# Altium schematic sheet Battery Pack BP8.SchDoc
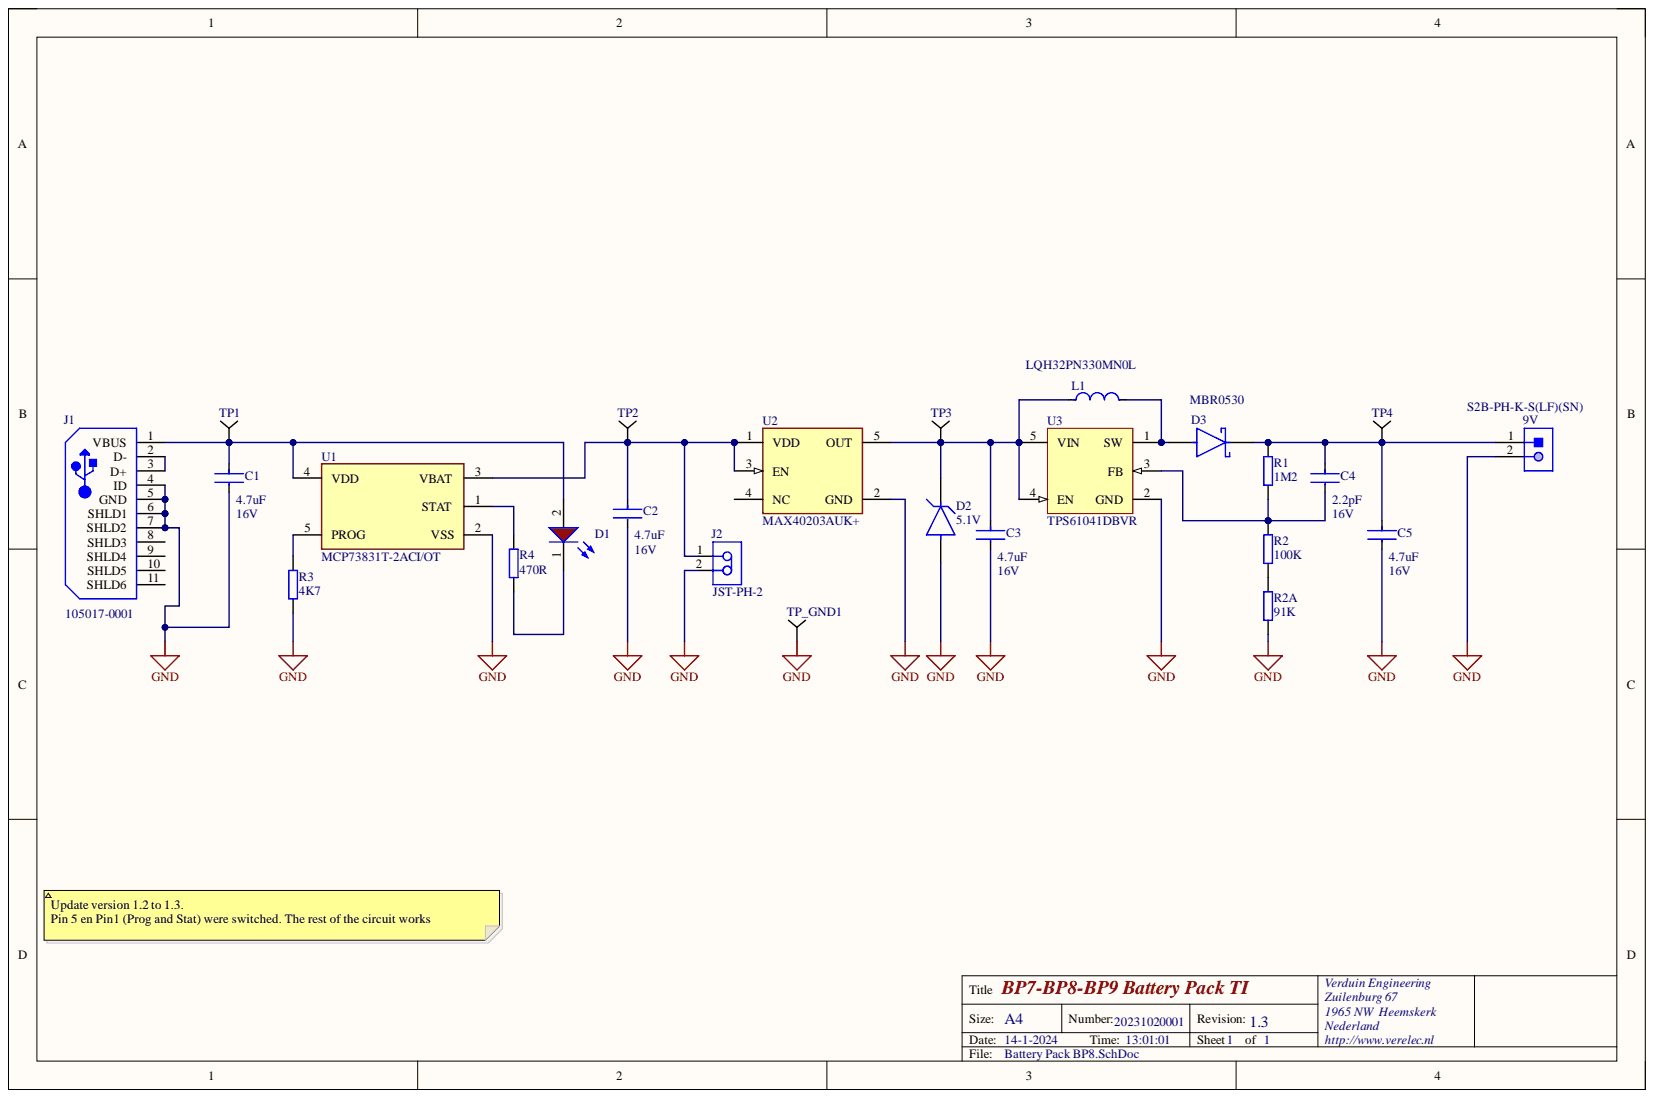
[diagram p0: Battery Pack BP8.SchDoc]

## Parts
9V  S2B-PH-K-S(LF)(SN)  [JST-S2B-PH-K-S_V]
C1  value 4.7uF  Capacitance: 4.7uF  Voltage Rating: 16V  [FP-0805-L_2_01_0_2-W_1_25-MFG]  (hidden comment: GCM21BR71C475KA73K)
C2  value 4.7uF  Capacitance: 4.7uF  Voltage Rating: 16V  [FP-0805-L_2_01_0_2-W_1_25-MFG]  (hidden comment: GCM21BR71C475KA73K)
C3  value 4.7uF  Capacitance: 4.7uF  Voltage Rating: 16V  [FP-0805-L_2_01_0_2-W_1_25-MFG]  (hidden comment: GCM21BR71C475KA73K)
C4  value 2.2pF  Capacitance: 2.2pF  Voltage Rating: 16V  [FP-0805-L_2_01_0_2-W_1_25-MFG]  (hidden comment: C0805C229C2GACTU)
C5  value 4.7uF  Capacitance: 4.7uF  Voltage Rating: 16V  [FP-0805-L_2_01_0_2-W_1_25-MFG]  (hidden comment: GCM21BR71C475KA73K)
D1  150080RS75000  [WL-SMCW_0805_150080xx75000]  (value not drawn)
D2  Nom Zener Voltage: 5.1V  [FP-SOD123-MFG]  (hidden comment: BZT52C5V1-7-F)
D3  MBR0530  [FP-425-04-MFG]
J1  105017-0001  [USB-MICRO-B_V]
J2  value JST-PH-2  [JST-B2B-PH-K-S_V]  (hidden comment: B2BPHKSLFSN)
L1  LQH32PN330MN0L  [FP-LQH32PB_N0-MFG]
R1  value 1M2  [RES0805]  (hidden comment: RES)
R2  value 100K  [RES0805]  (hidden comment: RES)
R2A  value 91K  [RES0805]  (hidden comment: RES)
R3  value 4K7  [RES0805]  (hidden comment: RES)
R4  value 470R  [RES0805]  (hidden comment: RES)
TP1  TESTPOINT  [TP-TOP]  (value not drawn)
TP2  TESTPOINT  [TP-TOP]  (value not drawn)
TP3  TESTPOINT  [TP-TOP]  (value not drawn)
TP4  TESTPOINT  [TP-TOP]  (value not drawn)
TP_GND1  TESTPOINT  [TP-TOP]  (value not drawn)
U1  MCP73831T-2ACI/OT  [SOT23-5]
U2  MAX40203AUK+  [FP-U5-2-MFG]
U3  TPS61041DBVR  [DBV0005A_M]

## Nets
GND: 9V.2 C1.2 C2.2 C3.2 C5.2 D2.2 J1.4(ID) J1.5(GND) J1.6(SHLD1) J1.7(SHLD2) J2.2 R2A.1 R3.1 TP_GND1.0 U1.2(VSS) U2.2(GND) U3.2(GND)
Net9V_1: 9V.1 C4.1 C5.1 D3.1 R1.2 TP4.0
NetC1_1: C1.1 D1.2 J1.1(VBUS) TP1.0 U1.4(VDD)
NetC2_1: C2.1 J2.1 TP2.0 U1.3(VBAT) U2.1(VDD) U2.3(EN)
NetC3_1: C3.1 D2.1 L1.1 TP3.0 U2.5(OUT) U3.4(EN) U3.5(VIN)
NetC4_2: C4.2 R1.1 R2.2 U3.3(FB)
NetD1_1: D1.1 R4.1
NetD3_2: D3.2 L1.2 U3.1(SW)
NetJ1_2: J1.2(D-) J1.3(D+)
NetR2_1: R2.1 R2A.2
NetR3_2: R3.2 U1.5(PROG)
NetR4_2: R4.2 U1.1(STAT)

Not connected: J1 8(SHLD3) 9(SHLD4) 10(SHLD5) 11(SHLD6); U2 4(NC)

## Library data of the parts
9V = CMP-1755-00004-1: Male Header, Pitch 2 mm, 1 x 2 Position, Height 4.8 mm, Tail Length 3.4 mm, -25 to 85 degC, RoHS, Bulk; Capacitance: 10 uF; Case\Package: Radial; Tolerance: 20%; Voltage Rating: 50 V; Component Kind: Standard; ComponentLink1Description: Datasheet; ComponentLink1URL: http://www.jst-mfg.com/product/pdf/eng/ePH.pdf; DatasheetVersion: Dec-12; Diameter: 5 mm; Height: 11 mm; Lead Pitch: 2 mm; Length: 11 mm; Library Name: Altium Content Vault; Max Operating Temperature: 85 degC; Min Operating Temperature: -40 degC; Mount: Through Hole; Package Quantity: 200; PackageDescription: Male Header, Pitch 2 mm, 1 x 2 Position, Height 4.8 mm, Tail Length 3.4 mm; PackageReference: S2B-PH-K-S; Packaging: Bulk; Pins: 2; Radiation Hardening: No; REACH SVHC: No SVHC; RoHSCompliant: true; Voltage Rating (DC): 50 V
C1-C5 = CMP-2007-04518-2: General Purpose Ceramic Capacitor, 0805, 4.7uF, 10%, X7R, 15%, 16V; Dissipation Factor: 3.5%; Voltage: 10V; Case Code (Imperial): 0805; Case Code (Metric): 2012; Case/Package: 0805; Component Kind: Standard; Construction: Flat; Contact Plating: Tin; Depth: 1.25mm; Dielectric: X7R; Height: 1.52mm; Lead Free: Lead Free; Length: 2.01mm; Library Name: Altium Content Vault; Max Operating Temperature: 125°C; Min Operating Temperature: -55°C; Mount: Surface Mount; Number of Pins: 2; Package Quantity: 1; Packaging: Tape and Reel; Radiation Hardening: No; REACH SVHC: No SVHC; RoHS Compliant: Yes; Series: -; Termination: SMD/SMT; Thickness: 0.06inch; Tolerance: 10%; Voltage Rating (DC): 16V; Width: 0.049inch
D1 = CMP-1426-00011-2: NexarPartId: 29717781; Color: Red; Dominant Wavelength: 625nm; Forward Current: 100mA; Forward Voltage: 2V; Lens Style: Clear, Rectangular; Luminous Intensity: 150mcd; Max Reverse Voltage (DC): 5V; Peak Wavelength: 630nm; Test Current: 20mA; Viewing Angle: 140°; Case Code (Imperial): 0805; Case Code (Metric): 2012; Case/Package: 0805; Component Kind: Standard; Height: 700µm; Length: 2mm; Library Name: Altium Content Vault; Max Operating Temperature: 85°C; Min Operating Temperature: -40°C; Mount: Surface Mount; Packaging: Tape and Reel; REACH SVHC: Unknown; RoHS: Compliant; Wavelength: 625nm; Width: 1.25mm
D2 = CMP-2000-05976-2: DIODE ZENER 5.1V 500MW SOD123; Manufacturer: Diodes Incorporated; Automotive Grade: NA; Forward Current: 10mA; Max Junction Temp: +150°C; Max Reverse Leakage Current: 2uA; Max Zener Voltage: 5.4V; Power Dissipation: 370mW; Standoff Height: 0mm; Zener Impedance: 60Ω; Automotive: No; Category: Diode; Component Kind: Standard; ComponentLink2Description: Datasheet; ComponentLink2URL: https://www.diodes.com/assets/Datasheets/ds18004.pdf; Device Class L1: Discrete Semiconductors; Device Class L2: Diodes; Device Class L3: Zener Diodes; Digikey Description: DIODE ZENER 5.1V 500MW SOD123; Footprint Url: https://www.diodes.com/assets/Package-Files/SOD123.pdf; Height: 1.45mm; Lead Free: Yes; Library Name: Altium Content Vault; Manufacturer Part Number: BZT52C5V1-7-F; Mouser Description: Zener Diodes 5.1V 500mW; Octopart: https://octopart.com/bzt52c5v1-7-f-diodes+inc.-326718; Package: SOD123; RoHS: TRUE; Temperature: -65°C to +150°C; Temperature Range High: +150°C; Temperature Range Low: -65°C
D3 = CMP-2000-05113-2: DIODE SCHOTTKY 30V 500MA SOD123; Element Configuration: Single; Forward Voltage: 430mV; Max Forward Surge Current (Ifsm): 5.5A; Max Reverse Leakage Current: 130uA; Polarity: Standard; Average Rectified Current: 500mA; Component Kind: Standard; Contact Plating: Tin; Current Rating: 500mA; Forward Current: 500mA; Height: 1.03mm; Lead Free: Lead Free; Length: 2.7mm; Library Name: Altium Content Vault; Max Operating Temperature: 150°C; Max Repetitive Reverse Voltage (Vrrm): 30V; Max Reverse Voltage (DC): 30V; Max Surge Current: 5.5A; Min Operating Temperature: -65°C; Mount: Surface Mount; Number of Pins: 2; Output Current: 500mA; Package Quantity: 1; Packaging: Tape and Reel; Peak Non-Repetitive Surge Current: 5.5A; Peak Reverse Current: 130uA; Radiation Hardening: No; REACH SVHC: No SVHC; Voltage Rating (DC): 30V; Weight: 0.03g; Width: 1.6mm
J1 = CMP-2000-05827-1: Micro-USB B Receptacle, Right Angle, Bottom Mount, Surface Mount, with Solder Tabs, -30 to 85 degC, 5-Pin USB, RoHS, Tape and Reel; Manufacturer: Molex; 3D Model: 105017-0001.stp; Catalog Drawings: 1050170001; Circuits: 5; Connector Type: USB-microB; Featured Product: Micro-USBConnectorsUSBConnectors; Mating Products: WM17145-ND-CABLEMICROUSBBTOSTDA1.0MWM17147-ND-CABLEMICROUSBBTOSTDA2.0MWM17146-ND-CABLEMICROUSBBTOSTDA1.5M; Online Catalog: Molex-MicroUSB; Other Names: WM1399DKR; Package Version: Rev. F, 12/2012; PCN Assembly/Origin: ResinSupplier21/Oct/2015; Voltage - Max: 30V; Category: Connectors,Interconnects; Component Kind: Standard; Datasheet URL: http://www.molex.com/elqNow/elqRedir.htm?ref=http://www.molex.com/webdocs/datasheets/pdf/en-us/1050170001_IO_CONNECTORS.pdf; Datasheet Version: 03/2016; Family: USB,DVI,HDMIConnectors; Features: Shielded,SolderRetention; Gender: Receptacle; Ingress Protection: -; Library Name: Altium Content Vault; Manufacturer URL: http://www.molex.com/; Mounting Technology: SurfaceMount; Mounting Type: BoardCutout,SurfaceMount,RightAngle; Number of Contacts: 5; Package Description: 5-Leads USB, Micro B, Body 7.5 x 5 mm, Pitch 0.65 mm; Package Reference: MICRO-B; Package URL: http://www.molex.com/pdm_docs/sd/1050170001_sd.pdf; Packaging: Digi-Reel®; RoHS Information: 1050170001CertofCompliance; Series: -; Specifications: -; Standard Package: 1
J2 = CMP-002-00127-1: Connector Header, PH Series, 2 Position, 2.00mm, Through Hole, Press-Fit, Solder, Natural; Component Kind: Standard; Library Name: NicoVerduin_Workspace
L1 = CMP-06042-000935-1: Wire Wound Ferrite Inductor for Power Lines 33μH ±20% 1.38Ω 390mA 1210; DC Resistance (DCR): 1.38R; Inductance: 33uH; Max DC Current: 380mA; Self Resonant Frequency: 13MHz; Shielding: Shielded; Test Frequency: 1MHz; Case Code (Imperial): 1210; Case Code (Metric): 3225; Case/Package: 1210; Component Kind: Standard; Core Material: Ferrite; Current Rating: 390mA; Depth: 2.5mm; Height: 2mm; Height - Seated (Max): 0.086inch; Length: 3.2mm; Library Name: Altium Content Vault; Max Operating Temperature: 85°C; Min Operating Temperature: -40°C; Mount: Surface Mount; Package Quantity: 2000; Packaging: Tape and Reel; Resistor Type: Wirewound; Saturation Current: 380mA; Termination: SMD/SMT; Tolerance: 20%; Weight: 0.001552oz; Width: 0.098inch
R1 R3 R4 = RES-SM-0805-NC: RES SM 0805 NC; Manufacturer: GENERIC; Database Table Name: resistors$; Component Kind: Standard; Library Name: electronpowered.dblib; Package: 0805; Part Number: RES-SM-0805-NC
R2 = RES-SM-0805-NC: RES SM 0805 NC; Manufacturer: Vishay; Part Number: CRCW0805100KFKEA; Database Table Name: resistors$; Component Kind: Standard; Library Name: electronpowered.dblib; Package: 0805
R2A = RES-SM-0805-NC: RES SM 0805 NC; Manufacturer: GENERIC; Part Number: CRCW080591K0FKEA; Database Table Name: resistors$; Component Kind: Standard; Library Name: electronpowered.dblib; Package: 0805
TP1-TP4 TP_GND1 = CMP-029-000000-1: Component Kind: Standard; Description: TESTPOINT; Part Number: TESTPOINT; Library Name: NicoVerduin_Workspace
U1 = CMP-031-00106-1: Power Supply Support Circuit, Adjustable, 1 Channel, PDSO5; Max Supply Voltage: 6V; Min Supply Voltage: 3.75V; Case/Package: LSSOP; Component Kind: Standard; Max Operating Temperature: 85°C; Min Operating Temperature: -40°C; Pins: 5; RoHS Compliant: Yes; Library Name: NicoVerduin_Workspace
U2 = CMP-05723-000008-1: IC IDEAL DIODE; Manufacturer: Maxim Integrated; Max Junction Temp: +150°C; Max Power Dissipation: 312.6mW; Max Supply Voltage: 5.5V; Min Supply Voltage: 1.2V; Nominal Supply Current: 0.13-0.3uA; Number Of Outputs: 1; Output Current: 2A; Standoff Height: 0mm; Automotive: No; Category: IC; Component Kind: Standard; ComponentLink2Description: Datasheet; ComponentLink2URL: https://datasheets.maximintegrated.com/en/ds/MAX40203.pdf; Device Class L1: Integrated Circuits (ICs); Device Class L2: Power Management ICs; Device Class L3: Voltage Supervisors; Digikey Description: IC IDEAL DIODE; Footprint Url: https://pdfserv.maximintegrated.com/land_patterns/90-0174.PDF; Height: 1.45mm; Ipc Land Pattern Name: SOT95P280X125-5; Lead Free: Yes; Library Name: Altium Content Vault; Manufacturer Part Number: MAX40203AUK+; Number Of Rails Monitored: 1; Octopart: https://octopart.com/max40203auk%2B-maxim+integrated-97007183; Package: U5-2; RoHS: TRUE; Temperature: -40°C to +125°C; Temperature Range High: +125°C; Temperature Range Low: -40°C
U3 = CMP-0388-00116-2: 28 V, 250 mA Switch Boost Converter for LCD and White LED Applications, 1.8 to 6 V, -40 to 85 degC, 5-pin SOT23 (DBV5), Green (RoHS & no Sb/Br); Manufacturer: Texas Instruments; Dimming: TRUE; Iout(Max)(A): 0.05; Iq(Typ)(mA): 0.028; Rating: Catalog; SubFamily: White LED Driver; Switching Frequency(Max)(kHz): 1000; Vin(Max)(V): 6; Vin(Min)(V): 1.8; Code_JEDEC: MO-178; Component Kind: Standard; ComponentLink1Description: Manufacturer URL; ComponentLink1URL: http://www.ti.com/; ComponentLink2Description: Datasheet; ComponentLink2URL: http://www.ti.com/general/docs/lit/getliterature.tsp?genericPartNumber=TPS61041&fileType=pdf; ComponentLink3Description: Package Specification; ComponentLink3URL: http://www.ti.com/litv/pdf/mpds018i; DatasheetVersion: SLVS413F; Eco-Plan: Green (RoHS & no Sb/Br); Library Name: Altium Content Vault; Mounting Technology: Surface Mount; PackageDescription: 5-Pin Plastic Small Outline, Body 1.60 Ãƒâ€” 2.90 mm, Pitch 0.95 mm; PackageReference: DBV0005A; PackageVersion: 4073253-4/K; PartNumber: TPS61041DBVR; Topology: Boost
